# Altium schematic sheet 07_DDR_32.SchDoc(07_DDR_32)
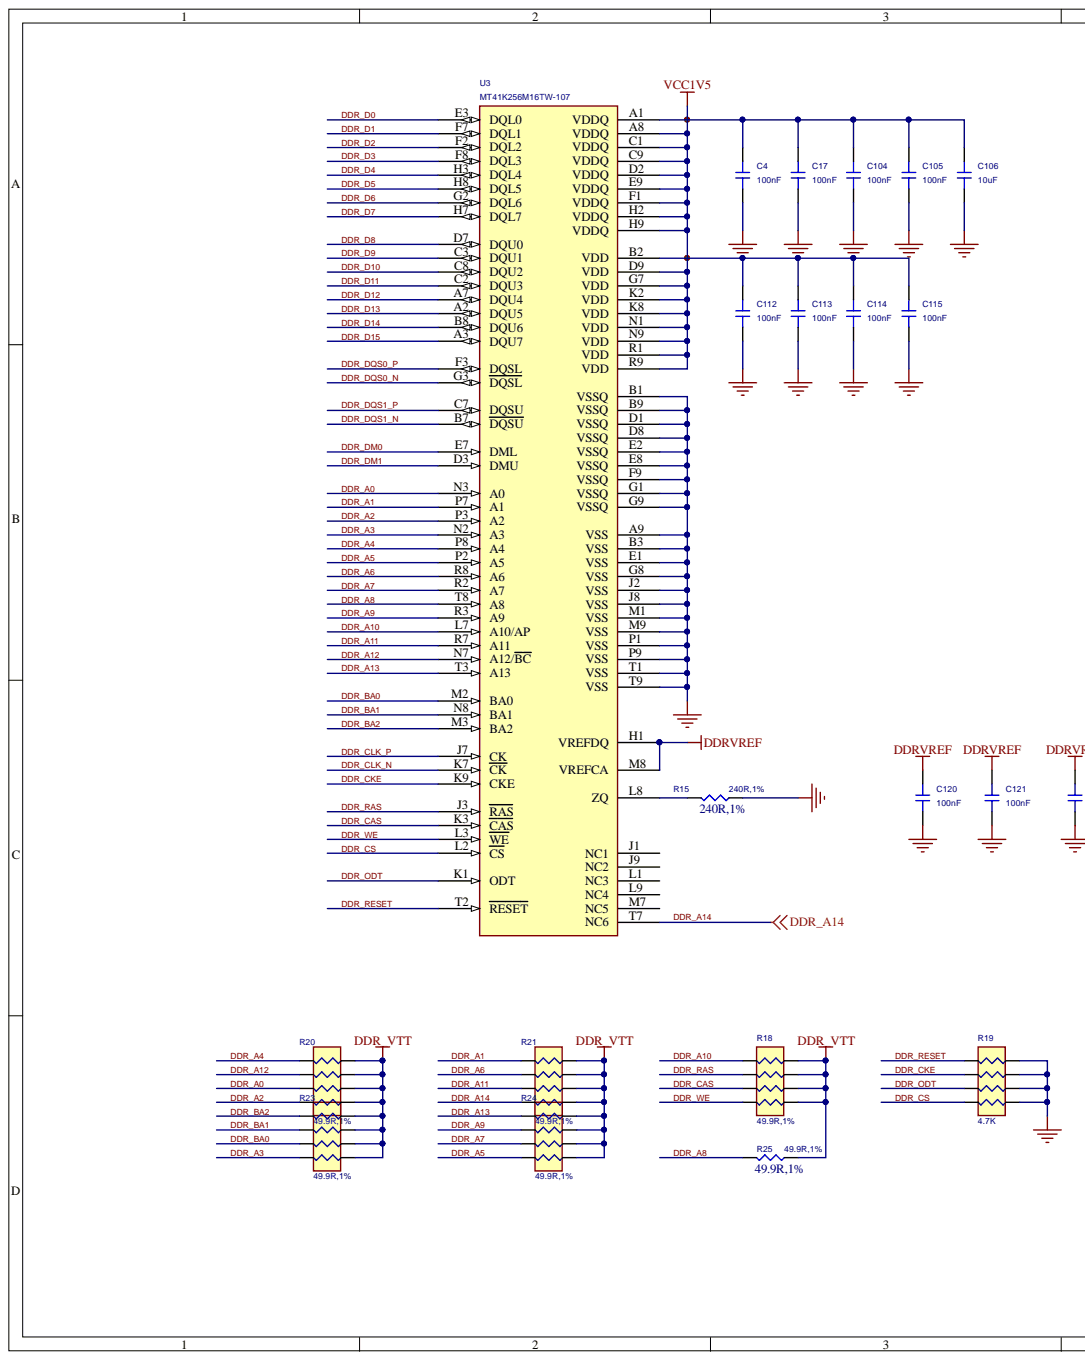
[diagram p0: 07_DDR_32.SchDoc, left]
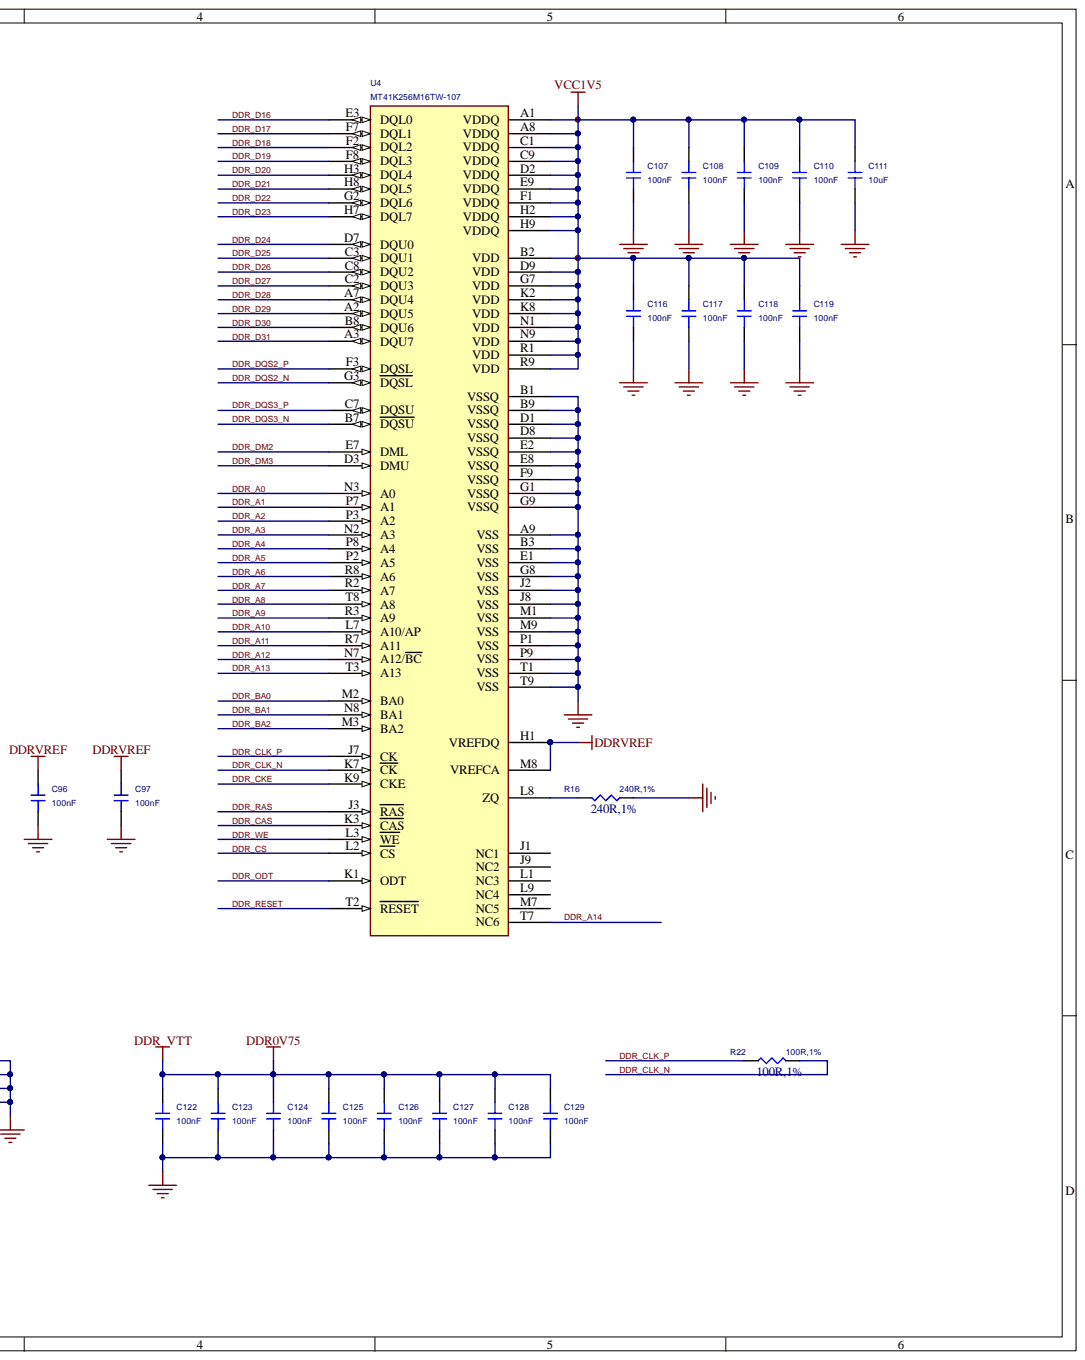
[diagram p1: 07_DDR_32.SchDoc, right]

## Parts
C4  100nF  [C0402]
C17  100nF  [C0402]
C96  100nF  [C0402]
C97  100nF  [C0402]
C104  100nF  [C0402]
C105  100nF  [C0402]
C106  10uF  [C0402]
C107  100nF  [C0402]
C108  100nF  [C0402]
C109  100nF  [C0402]
C110  100nF  [C0402]
C111  10uF  [C0402]
C112  100nF  [C0402]
C113  100nF  [C0402]
C114  100nF  [C0402]
C115  100nF  [C0402]
C116  100nF  [C0402]
C117  100nF  [C0402]
C118  100nF  [C0402]
C119  100nF  [C0402]
C120  100nF  [C0402]
C121  100nF  [C0402]
C122  100nF  [C0402]
C123  100nF  [C0402]
C124  100nF  [C0402]
C125  100nF  [C0402]
C126  100nF  [C0402]
C127  100nF  [C0402]
C128  100nF  [C0402]
C129  100nF  [C0402]
R15  240R,1%  [R0402]
R16  240R,1%  [R0402]
R18  49.9R,1%  [RA4_0402]
R19  4.7K  [RA4_0402]
R20  49.9R,1%  [RA4_0402]
R21  49.9R,1%  [RA4_0402]
R22  100R,1%  [R0402]
R23  49.9R,1%  [RA4_0402]
R24  49.9R,1%  [RA4_0402]
R25  49.9R,1%  [RES0402]
U3  MT41K256M16TW-107  [BGA96_80P7_5X13_3X1]
U4  MT41K256M16TW-107  [BGA96_80P7_5X13_3X1]

## Nets
DDR0V75: C122.2 C123.2 C124.2 C125.2 C126.2 C127.2 C128.2 C129.2 R18.5 R18.6 R18.7 R18.8 R20.5 R20.6 R20.7 R20.8 R21.5 R21.6 R21.7 R21.8 R23.5 R23.6 R23.7 R23.8 R24.5 R24.6 R24.7 R24.8 R25.2
DDRVREF: C96.2 C97.2 C120.2 C121.2 U3.H1(VREFDQ) U3.M8(VREFCA) U4.H1(VREFDQ) U4.M8(VREFCA)
GND: C4.1 C17.1 C96.1 C97.1 C104.1 C105.1 C106.1 C107.1 C108.1 C109.1 C110.1 C111.1 C112.1 C113.1 C114.1 C115.1 C116.1 C117.1 C118.1 C119.1 C120.1 C121.1 C122.1 C123.1 C124.1 C125.1 C126.1 C127.1 C128.1 C129.1 R15.2 R16.2 R19.5 R19.6 R19.7 R19.8 U3.A9(VSS) U3.B1(VSSQ) U3.B3(VSS) U3.B9(VSSQ) U3.D1(VSSQ) U3.D8(VSSQ) U3.E1(VSS) U3.E2(VSSQ) U3.E8(VSSQ) U3.F9(VSSQ) U3.G1(VSSQ) U3.G8(VSS) U3.G9(VSSQ) U3.J2(VSS) U3.J8(VSS) U3.M1(VSS) U3.M9(VSS) U3.P1(VSS) U3.P9(VSS) U3.T1(VSS) U3.T9(VSS) U4.A9(VSS) U4.B1(VSSQ) U4.B3(VSS) U4.B9(VSSQ) U4.D1(VSSQ) U4.D8(VSSQ) U4.E1(VSS) U4.E2(VSSQ) U4.E8(VSSQ) U4.F9(VSSQ) U4.G1(VSSQ) U4.G8(VSS) U4.G9(VSSQ) U4.J2(VSS) U4.J8(VSS) U4.M1(VSS) U4.M9(VSS) U4.P1(VSS) U4.P9(VSS) U4.T1(VSS) U4.T9(VSS)
VCC1V5: C4.2 C17.2 C104.2 C105.2 C106.2 C107.2 C108.2 C109.2 C110.2 C111.2 C112.2 C113.2 C114.2 C115.2 C116.2 C117.2 C118.2 C119.2 U3.A1(VDDQ) U3.A8(VDDQ) U3.B2(VDD) U3.C1(VDDQ) U3.C9(VDDQ) U3.D2(VDDQ) U3.D9(VDD) U3.E9(VDDQ) U3.F1(VDDQ) U3.G7(VDD) U3.H2(VDDQ) U3.H9(VDDQ) U3.K2(VDD) U3.K8(VDD) U3.N1(VDD) U3.N9(VDD) U3.R1(VDD) U3.R9(VDD) U4.A1(VDDQ) U4.A8(VDDQ) U4.B2(VDD) U4.C1(VDDQ) U4.C9(VDDQ) U4.D2(VDDQ) U4.D9(VDD) U4.E9(VDDQ) U4.F1(VDDQ) U4.G7(VDD) U4.H2(VDDQ) U4.H9(VDDQ) U4.K2(VDD) U4.K8(VDD) U4.N1(VDD) U4.N9(VDD) U4.R1(VDD) U4.R9(VDD)
DDR_A0: R20.3 U3.N3(A0) U4.N3(A0)
DDR_A1: R21.1 U3.P7(A1) U4.P7(A1)
DDR_A2: R20.4 U3.P3(A2) U4.P3(A2)
DDR_A3: R23.4 U3.N2(A3) U4.N2(A3)
DDR_A4: R20.1 U3.P8(A4) U4.P8(A4)
DDR_A5: R24.4 U3.P2(A5) U4.P2(A5)
DDR_A6: R21.2 U3.R8(A6) U4.R8(A6)
DDR_A7: R24.3 U3.R2(A7) U4.R2(A7)
DDR_A8: R25.1 U3.T8(A8) U4.T8(A8)
DDR_A9: R24.2 U3.R3(A9) U4.R3(A9)
DDR_A10: R18.1 U3.L7(A10/AP) U4.L7(A10/AP)
DDR_A11: R21.3 U3.R7(A11) U4.R7(A11)
DDR_A12: R20.2 U3.N7(A12/BC) U4.N7(A12/BC)
DDR_A13: R24.1 U3.T3(A13) U4.T3(A13)
DDR_A14: R21.4 U3.T7(NC6) U4.T7(NC6)
DDR_BA0: R23.3 U3.M2(BA0) U4.M2(BA0)
DDR_BA1: R23.2 U3.N8(BA1) U4.N8(BA1)
DDR_BA2: R23.1 U3.M3(BA2) U4.M3(BA2)
DDR_CAS: R18.3 U3.K3(CAS) U4.K3(CAS)
DDR_CKE: R19.2 U3.K9(CKE) U4.K9(CKE)
DDR_CLK_N: R22.1 U3.K7(CK) U4.K7(CK)
DDR_CLK_P: R22.2 U3.J7(CK) U4.J7(CK)
DDR_CS: R19.4 U3.L2(CS) U4.L2(CS)
DDR_D0: U3.E3(DQL0)
DDR_D1: U3.F7(DQL1)
DDR_D2: U3.F2(DQL2)
DDR_D3: U3.F8(DQL3)
DDR_D4: U3.H3(DQL4)
DDR_D5: U3.H8(DQL5)
DDR_D6: U3.G2(DQL6)
DDR_D7: U3.H7(DQL7)
DDR_D8: U3.D7(DQU0)
DDR_D9: U3.C3(DQU1)
DDR_D10: U3.C8(DQU2)
DDR_D11: U3.C2(DQU3)
DDR_D12: U3.A7(DQU4)
DDR_D13: U3.A2(DQU5)
DDR_D14: U3.B8(DQU6)
DDR_D15: U3.A3(DQU7)
DDR_D16: U4.E3(DQL0)
DDR_D17: U4.F7(DQL1)
DDR_D18: U4.F2(DQL2)
DDR_D19: U4.F8(DQL3)
DDR_D20: U4.H3(DQL4)
DDR_D21: U4.H8(DQL5)
DDR_D22: U4.G2(DQL6)
DDR_D23: U4.H7(DQL7)
DDR_D24: U4.D7(DQU0)
DDR_D25: U4.C3(DQU1)
DDR_D26: U4.C8(DQU2)
DDR_D27: U4.C2(DQU3)
DDR_D28: U4.A7(DQU4)
DDR_D29: U4.A2(DQU5)
DDR_D30: U4.B8(DQU6)
DDR_D31: U4.A3(DQU7)
DDR_DM0: U3.E7(DML)
DDR_DM1: U3.D3(DMU)
DDR_DM2: U4.E7(DML)
DDR_DM3: U4.D3(DMU)
DDR_DQS0_N: U3.G3(DQSL)
DDR_DQS0_P: U3.F3(DQSL)
DDR_DQS1_N: U3.B7(DQSU)
DDR_DQS1_P: U3.C7(DQSU)
DDR_DQS2_N: U4.G3(DQSL)
DDR_DQS2_P: U4.F3(DQSL)
DDR_DQS3_N: U4.B7(DQSU)
DDR_DQS3_P: U4.C7(DQSU)
DDR_ODT: R19.3 U3.K1(ODT) U4.K1(ODT)
DDR_RAS: R18.2 U3.J3(RAS) U4.J3(RAS)
DDR_RESET: R19.1 U3.T2(RESET) U4.T2(RESET)
DDR_WE: R18.4 U3.L3(WE) U4.L3(WE)
NetR15_1: R15.1 U3.L8(ZQ)
NetR16_1: R16.1 U4.L8(ZQ)

Not connected: U3 J1(NC1) J9(NC2) L1(NC3) L9(NC4) M7(NC5); U4 J1(NC1) J9(NC2) L1(NC3) L9(NC4) M7(NC5)

## Library data of the parts
C4 = CAP1_Dup1: CURRENT: CIMAX; DIST: FLAT; KNEE: CBMAX; MAX_TEMP: CTMAX; PSpiceTemplate: C^@REFDES %1 %2 ?TOLERANCE|C^@REFDES| @VALUE ?IC/IC=@IC/ TC=@TC1,@TC2 ?TOLERANCE|\n.model C^@REFDES CAP C=1 DEV=@TOLERANCE% TC1=@TC1 TC2=@TC2 VC1=@VC1 VC2=@VC2|; REUSE_ANNOTATE: TRUE; REUSE_ID: 13; SLOPE: CSMAX; TC1: 0; VOLTAGE: CMAX; Component Kind: Standard; Simulation: CAP1; TC2: 0; Value: 100nF; VC1: 0; VC2: 0
C17 = CAP1_Dup1: CURRENT: CIMAX; DIST: FLAT; KNEE: CBMAX; MAX_TEMP: CTMAX; PSpiceTemplate: C^@REFDES %1 %2 ?TOLERANCE|C^@REFDES| @VALUE ?IC/IC=@IC/ TC=@TC1,@TC2 ?TOLERANCE|\n.model C^@REFDES CAP C=1 DEV=@TOLERANCE% TC1=@TC1 TC2=@TC2 VC1=@VC1 VC2=@VC2|; REUSE_ANNOTATE: TRUE; REUSE_ID: 347; SLOPE: CSMAX; TC1: 0; VOLTAGE: CMAX; Component Kind: Standard; Simulation: CAP1; TC2: 0; Value: 100nF; VC1: 0; VC2: 0
C96 C97 C120 C121 = CAP1_Dup1: CURRENT: CIMAX; DIST: FLAT; KNEE: CBMAX; MAX_TEMP: CTMAX; PSpiceTemplate: C^@REFDES %1 %2 ?TOLERANCE|C^@REFDES| @VALUE ?IC/IC=@IC/ TC=@TC1,@TC2 ?TOLERANCE|\n.model C^@REFDES CAP C=1 DEV=@TOLERANCE% TC1=@TC1 TC2=@TC2 VC1=@VC1 VC2=@VC2|; REUSE_ANNOTATE: TRUE; REUSE_ID: 77; SLOPE: CSMAX; TC1: 0; VOLTAGE: CMAX; Component Kind: Standard; Simulation: CAP1; TC2: 0; Value: 100nF; VC1: 0; VC2: 0
C104 = CAP1_Dup1: CURRENT: CIMAX; DIST: FLAT; KNEE: CBMAX; MAX_TEMP: CTMAX; PSpiceTemplate: C^@REFDES %1 %2 ?TOLERANCE|C^@REFDES| @VALUE ?IC/IC=@IC/ TC=@TC1,@TC2 ?TOLERANCE|\n.model C^@REFDES CAP C=1 DEV=@TOLERANCE% TC1=@TC1 TC2=@TC2 VC1=@VC1 VC2=@VC2|; REUSE_ANNOTATE: TRUE; REUSE_ID: 349; SLOPE: CSMAX; TC1: 0; VOLTAGE: CMAX; Component Kind: Standard; Simulation: CAP1; TC2: 0; Value: 100nF; VC1: 0; VC2: 0
C105 = CAP1_Dup1: CURRENT: CIMAX; DIST: FLAT; KNEE: CBMAX; MAX_TEMP: CTMAX; PSpiceTemplate: C^@REFDES %1 %2 ?TOLERANCE|C^@REFDES| @VALUE ?IC/IC=@IC/ TC=@TC1,@TC2 ?TOLERANCE|\n.model C^@REFDES CAP C=1 DEV=@TOLERANCE% TC1=@TC1 TC2=@TC2 VC1=@VC1 VC2=@VC2|; REUSE_ANNOTATE: TRUE; REUSE_ID: 11; SLOPE: CSMAX; TC1: 0; VOLTAGE: CMAX; Component Kind: Standard; Simulation: CAP1; TC2: 0; Value: 100nF; VC1: 0; VC2: 0
C106 = CAP1_Dup1: CURRENT: CIMAX; DIST: FLAT; KNEE: CBMAX; MAX_TEMP: CTMAX; PSpiceTemplate: C^@REFDES %1 %2 ?TOLERANCE|C^@REFDES| @VALUE ?IC/IC=@IC/ TC=@TC1,@TC2 ?TOLERANCE|\n.model C^@REFDES CAP C=1 DEV=@TOLERANCE% TC1=@TC1 TC2=@TC2 VC1=@VC1 VC2=@VC2|; REUSE_ANNOTATE: TRUE; REUSE_ID: 348; SLOPE: CSMAX; TC1: 0; VOLTAGE: CMAX; Component Kind: Standard; Simulation: CAP1; TC2: 0; Value: 10uF; VC1: 0; VC2: 0
C107 = CAP1_Dup1: CURRENT: CIMAX; DIST: FLAT; KNEE: CBMAX; MAX_TEMP: CTMAX; PSpiceTemplate: C^@REFDES %1 %2 ?TOLERANCE|C^@REFDES| @VALUE ?IC/IC=@IC/ TC=@TC1,@TC2 ?TOLERANCE|\n.model C^@REFDES CAP C=1 DEV=@TOLERANCE% TC1=@TC1 TC2=@TC2 VC1=@VC1 VC2=@VC2|; REUSE_ANNOTATE: TRUE; REUSE_ID: 353; SLOPE: CSMAX; TC1: 0; VOLTAGE: CMAX; Component Kind: Standard; Simulation: CAP1; TC2: 0; Value: 100nF; VC1: 0; VC2: 0
C108 = CAP1_Dup1: CURRENT: CIMAX; DIST: FLAT; KNEE: CBMAX; MAX_TEMP: CTMAX; PSpiceTemplate: C^@REFDES %1 %2 ?TOLERANCE|C^@REFDES| @VALUE ?IC/IC=@IC/ TC=@TC1,@TC2 ?TOLERANCE|\n.model C^@REFDES CAP C=1 DEV=@TOLERANCE% TC1=@TC1 TC2=@TC2 VC1=@VC1 VC2=@VC2|; REUSE_ANNOTATE: TRUE; REUSE_ID: 9; SLOPE: CSMAX; TC1: 0; VOLTAGE: CMAX; Component Kind: Standard; Simulation: CAP1; TC2: 0; Value: 100nF; VC1: 0; VC2: 0
C109 = CAP1_Dup1: CURRENT: CIMAX; DIST: FLAT; KNEE: CBMAX; MAX_TEMP: CTMAX; PSpiceTemplate: C^@REFDES %1 %2 ?TOLERANCE|C^@REFDES| @VALUE ?IC/IC=@IC/ TC=@TC1,@TC2 ?TOLERANCE|\n.model C^@REFDES CAP C=1 DEV=@TOLERANCE% TC1=@TC1 TC2=@TC2 VC1=@VC1 VC2=@VC2|; REUSE_ANNOTATE: TRUE; REUSE_ID: 8; SLOPE: CSMAX; TC1: 0; VOLTAGE: CMAX; Component Kind: Standard; Simulation: CAP1; TC2: 0; Value: 100nF; VC1: 0; VC2: 0
C110 = CAP1_Dup1: CURRENT: CIMAX; DIST: FLAT; KNEE: CBMAX; MAX_TEMP: CTMAX; PSpiceTemplate: C^@REFDES %1 %2 ?TOLERANCE|C^@REFDES| @VALUE ?IC/IC=@IC/ TC=@TC1,@TC2 ?TOLERANCE|\n.model C^@REFDES CAP C=1 DEV=@TOLERANCE% TC1=@TC1 TC2=@TC2 VC1=@VC1 VC2=@VC2|; REUSE_ANNOTATE: TRUE; REUSE_ID: 346; SLOPE: CSMAX; TC1: 0; VOLTAGE: CMAX; Component Kind: Standard; Simulation: CAP1; TC2: 0; Value: 100nF; VC1: 0; VC2: 0
C111 = CAP1_Dup1: CURRENT: CIMAX; DIST: FLAT; KNEE: CBMAX; MAX_TEMP: CTMAX; PSpiceTemplate: C^@REFDES %1 %2 ?TOLERANCE|C^@REFDES| @VALUE ?IC/IC=@IC/ TC=@TC1,@TC2 ?TOLERANCE|\n.model C^@REFDES CAP C=1 DEV=@TOLERANCE% TC1=@TC1 TC2=@TC2 VC1=@VC1 VC2=@VC2|; REUSE_ANNOTATE: TRUE; REUSE_ID: 352; SLOPE: CSMAX; TC1: 0; VOLTAGE: CMAX; Component Kind: Standard; Simulation: CAP1; TC2: 0; Value: 10uF; VC1: 0; VC2: 0
C112 = CAP1_Dup1: CURRENT: CIMAX; DIST: FLAT; KNEE: CBMAX; MAX_TEMP: CTMAX; PSpiceTemplate: C^@REFDES %1 %2 ?TOLERANCE|C^@REFDES| @VALUE ?IC/IC=@IC/ TC=@TC1,@TC2 ?TOLERANCE|\n.model C^@REFDES CAP C=1 DEV=@TOLERANCE% TC1=@TC1 TC2=@TC2 VC1=@VC1 VC2=@VC2|; REUSE_ANNOTATE: TRUE; REUSE_ID: 2; SLOPE: CSMAX; TC1: 0; VOLTAGE: CMAX; Component Kind: Standard; Simulation: CAP1; TC2: 0; Value: 100nF; VC1: 0; VC2: 0
C113 = CAP1_Dup1: CURRENT: CIMAX; DIST: FLAT; KNEE: CBMAX; MAX_TEMP: CTMAX; PSpiceTemplate: C^@REFDES %1 %2 ?TOLERANCE|C^@REFDES| @VALUE ?IC/IC=@IC/ TC=@TC1,@TC2 ?TOLERANCE|\n.model C^@REFDES CAP C=1 DEV=@TOLERANCE% TC1=@TC1 TC2=@TC2 VC1=@VC1 VC2=@VC2|; REUSE_ANNOTATE: TRUE; REUSE_ID: 14; SLOPE: CSMAX; TC1: 0; VOLTAGE: CMAX; Component Kind: Standard; Simulation: CAP1; TC2: 0; Value: 100nF; VC1: 0; VC2: 0
C114 = CAP1_Dup1: CURRENT: CIMAX; DIST: FLAT; KNEE: CBMAX; MAX_TEMP: CTMAX; PSpiceTemplate: C^@REFDES %1 %2 ?TOLERANCE|C^@REFDES| @VALUE ?IC/IC=@IC/ TC=@TC1,@TC2 ?TOLERANCE|\n.model C^@REFDES CAP C=1 DEV=@TOLERANCE% TC1=@TC1 TC2=@TC2 VC1=@VC1 VC2=@VC2|; REUSE_ANNOTATE: TRUE; REUSE_ID: 4; SLOPE: CSMAX; TC1: 0; VOLTAGE: CMAX; Component Kind: Standard; Simulation: CAP1; TC2: 0; Value: 100nF; VC1: 0; VC2: 0
C115 = CAP1_Dup1: CURRENT: CIMAX; DIST: FLAT; KNEE: CBMAX; MAX_TEMP: CTMAX; PSpiceTemplate: C^@REFDES %1 %2 ?TOLERANCE|C^@REFDES| @VALUE ?IC/IC=@IC/ TC=@TC1,@TC2 ?TOLERANCE|\n.model C^@REFDES CAP C=1 DEV=@TOLERANCE% TC1=@TC1 TC2=@TC2 VC1=@VC1 VC2=@VC2|; REUSE_ANNOTATE: TRUE; REUSE_ID: 10; SLOPE: CSMAX; TC1: 0; VOLTAGE: CMAX; Component Kind: Standard; Simulation: CAP1; TC2: 0; Value: 100nF; VC1: 0; VC2: 0
C116 = CAP1_Dup1: CURRENT: CIMAX; DIST: FLAT; KNEE: CBMAX; MAX_TEMP: CTMAX; PSpiceTemplate: C^@REFDES %1 %2 ?TOLERANCE|C^@REFDES| @VALUE ?IC/IC=@IC/ TC=@TC1,@TC2 ?TOLERANCE|\n.model C^@REFDES CAP C=1 DEV=@TOLERANCE% TC1=@TC1 TC2=@TC2 VC1=@VC1 VC2=@VC2|; REUSE_ANNOTATE: TRUE; REUSE_ID: 350; SLOPE: CSMAX; TC1: 0; VOLTAGE: CMAX; Component Kind: Standard; Simulation: CAP1; TC2: 0; Value: 100nF; VC1: 0; VC2: 0
C117 = CAP1_Dup1: CURRENT: CIMAX; DIST: FLAT; KNEE: CBMAX; MAX_TEMP: CTMAX; PSpiceTemplate: C^@REFDES %1 %2 ?TOLERANCE|C^@REFDES| @VALUE ?IC/IC=@IC/ TC=@TC1,@TC2 ?TOLERANCE|\n.model C^@REFDES CAP C=1 DEV=@TOLERANCE% TC1=@TC1 TC2=@TC2 VC1=@VC1 VC2=@VC2|; REUSE_ANNOTATE: TRUE; REUSE_ID: 15; SLOPE: CSMAX; TC1: 0; VOLTAGE: CMAX; Component Kind: Standard; Simulation: CAP1; TC2: 0; Value: 100nF; VC1: 0; VC2: 0
C118 = CAP1_Dup1: CURRENT: CIMAX; DIST: FLAT; KNEE: CBMAX; MAX_TEMP: CTMAX; PSpiceTemplate: C^@REFDES %1 %2 ?TOLERANCE|C^@REFDES| @VALUE ?IC/IC=@IC/ TC=@TC1,@TC2 ?TOLERANCE|\n.model C^@REFDES CAP C=1 DEV=@TOLERANCE% TC1=@TC1 TC2=@TC2 VC1=@VC1 VC2=@VC2|; REUSE_ANNOTATE: TRUE; REUSE_ID: 3; SLOPE: CSMAX; TC1: 0; VOLTAGE: CMAX; Component Kind: Standard; Simulation: CAP1; TC2: 0; Value: 100nF; VC1: 0; VC2: 0
C119 = CAP1_Dup1: CURRENT: CIMAX; DIST: FLAT; KNEE: CBMAX; MAX_TEMP: CTMAX; PSpiceTemplate: C^@REFDES %1 %2 ?TOLERANCE|C^@REFDES| @VALUE ?IC/IC=@IC/ TC=@TC1,@TC2 ?TOLERANCE|\n.model C^@REFDES CAP C=1 DEV=@TOLERANCE% TC1=@TC1 TC2=@TC2 VC1=@VC1 VC2=@VC2|; REUSE_ANNOTATE: TRUE; REUSE_ID: 345; SLOPE: CSMAX; TC1: 0; VOLTAGE: CMAX; Component Kind: Standard; Simulation: CAP1; TC2: 0; Value: 100nF; VC1: 0; VC2: 0
C122 = CAP1_Dup1: CURRENT: CIMAX; DIST: FLAT; KNEE: CBMAX; MAX_TEMP: CTMAX; PSpiceTemplate: C^@REFDES %1 %2 ?TOLERANCE|C^@REFDES| @VALUE ?IC/IC=@IC/ TC=@TC1,@TC2 ?TOLERANCE|\n.model C^@REFDES CAP C=1 DEV=@TOLERANCE% TC1=@TC1 TC2=@TC2 VC1=@VC1 VC2=@VC2|; REUSE_ANNOTATE: TRUE; REUSE_ID: 162; SLOPE: CSMAX; TC1: 0; VOLTAGE: CMAX; Component Kind: Standard; Simulation: CAP1; TC2: 0; Value: 100nF; VC1: 0; VC2: 0
C123 = CAP1_Dup1: CURRENT: CIMAX; DIST: FLAT; KNEE: CBMAX; MAX_TEMP: CTMAX; PSpiceTemplate: C^@REFDES %1 %2 ?TOLERANCE|C^@REFDES| @VALUE ?IC/IC=@IC/ TC=@TC1,@TC2 ?TOLERANCE|\n.model C^@REFDES CAP C=1 DEV=@TOLERANCE% TC1=@TC1 TC2=@TC2 VC1=@VC1 VC2=@VC2|; REUSE_ANNOTATE: TRUE; REUSE_ID: 165; SLOPE: CSMAX; TC1: 0; VOLTAGE: CMAX; Component Kind: Standard; Simulation: CAP1; TC2: 0; Value: 100nF; VC1: 0; VC2: 0
C124 = CAP1_Dup1: CURRENT: CIMAX; DIST: FLAT; KNEE: CBMAX; MAX_TEMP: CTMAX; PSpiceTemplate: C^@REFDES %1 %2 ?TOLERANCE|C^@REFDES| @VALUE ?IC/IC=@IC/ TC=@TC1,@TC2 ?TOLERANCE|\n.model C^@REFDES CAP C=1 DEV=@TOLERANCE% TC1=@TC1 TC2=@TC2 VC1=@VC1 VC2=@VC2|; REUSE_ANNOTATE: TRUE; REUSE_ID: 163; SLOPE: CSMAX; TC1: 0; VOLTAGE: CMAX; Component Kind: Standard; Simulation: CAP1; TC2: 0; Value: 100nF; VC1: 0; VC2: 0
C125 = CAP1_Dup1: CURRENT: CIMAX; DIST: FLAT; KNEE: CBMAX; MAX_TEMP: CTMAX; PSpiceTemplate: C^@REFDES %1 %2 ?TOLERANCE|C^@REFDES| @VALUE ?IC/IC=@IC/ TC=@TC1,@TC2 ?TOLERANCE|\n.model C^@REFDES CAP C=1 DEV=@TOLERANCE% TC1=@TC1 TC2=@TC2 VC1=@VC1 VC2=@VC2|; REUSE_ANNOTATE: TRUE; REUSE_ID: 164; SLOPE: CSMAX; TC1: 0; VOLTAGE: CMAX; Component Kind: Standard; Simulation: CAP1; TC2: 0; Value: 100nF; VC1: 0; VC2: 0
C126 = CAP1_Dup1: CURRENT: CIMAX; DIST: FLAT; KNEE: CBMAX; MAX_TEMP: CTMAX; PSpiceTemplate: C^@REFDES %1 %2 ?TOLERANCE|C^@REFDES| @VALUE ?IC/IC=@IC/ TC=@TC1,@TC2 ?TOLERANCE|\n.model C^@REFDES CAP C=1 DEV=@TOLERANCE% TC1=@TC1 TC2=@TC2 VC1=@VC1 VC2=@VC2|; REUSE_ANNOTATE: TRUE; REUSE_ID: 167; SLOPE: CSMAX; TC1: 0; VOLTAGE: CMAX; Component Kind: Standard; Simulation: CAP1; TC2: 0; Value: 100nF; VC1: 0; VC2: 0
C127 = CAP1_Dup1: CURRENT: CIMAX; DIST: FLAT; KNEE: CBMAX; MAX_TEMP: CTMAX; PSpiceTemplate: C^@REFDES %1 %2 ?TOLERANCE|C^@REFDES| @VALUE ?IC/IC=@IC/ TC=@TC1,@TC2 ?TOLERANCE|\n.model C^@REFDES CAP C=1 DEV=@TOLERANCE% TC1=@TC1 TC2=@TC2 VC1=@VC1 VC2=@VC2|; REUSE_ANNOTATE: TRUE; REUSE_ID: 170; SLOPE: CSMAX; TC1: 0; VOLTAGE: CMAX; Component Kind: Standard; Simulation: CAP1; TC2: 0; Value: 100nF; VC1: 0; VC2: 0
C128 = CAP1_Dup1: CURRENT: CIMAX; DIST: FLAT; KNEE: CBMAX; MAX_TEMP: CTMAX; PSpiceTemplate: C^@REFDES %1 %2 ?TOLERANCE|C^@REFDES| @VALUE ?IC/IC=@IC/ TC=@TC1,@TC2 ?TOLERANCE|\n.model C^@REFDES CAP C=1 DEV=@TOLERANCE% TC1=@TC1 TC2=@TC2 VC1=@VC1 VC2=@VC2|; REUSE_ANNOTATE: TRUE; REUSE_ID: 168; SLOPE: CSMAX; TC1: 0; VOLTAGE: CMAX; Component Kind: Standard; Simulation: CAP1; TC2: 0; Value: 100nF; VC1: 0; VC2: 0
C129 = CAP1_Dup1: CURRENT: CIMAX; DIST: FLAT; KNEE: CBMAX; MAX_TEMP: CTMAX; PSpiceTemplate: C^@REFDES %1 %2 ?TOLERANCE|C^@REFDES| @VALUE ?IC/IC=@IC/ TC=@TC1,@TC2 ?TOLERANCE|\n.model C^@REFDES CAP C=1 DEV=@TOLERANCE% TC1=@TC1 TC2=@TC2 VC1=@VC1 VC2=@VC2|; REUSE_ANNOTATE: TRUE; REUSE_ID: 166; SLOPE: CSMAX; TC1: 0; VOLTAGE: CMAX; Component Kind: Standard; Simulation: CAP1; TC2: 0; Value: 100nF; VC1: 0; VC2: 0
R15 = RES1: DIST: FLAT; MAX_TEMP: RTMAX; POWER: RMAX; PSpiceTemplate: R^@REFDES %1 %2 ?TOLERANCE|R^@REFDES| @VALUE TC=@TC1,@TC2 ?TOLERANCE|\n.model R^@REFDES RES R=1 DEV=@TOLERANCE% TC1=@TC1 TC2=@TC2|; REUSE_ANNOTATE: TRUE; REUSE_ID: 1; SLOPE: RSMAX; TC1: 0; VOLTAGE: RVMAX; Component Kind: Standard; Simulation: RES1; TC2: 0; Value: 240R,1%
R16 = RES1: DIST: FLAT; MAX_TEMP: RTMAX; POWER: RMAX; PSpiceTemplate: R^@REFDES %1 %2 ?TOLERANCE|R^@REFDES| @VALUE TC=@TC1,@TC2 ?TOLERANCE|\n.model R^@REFDES RES R=1 DEV=@TOLERANCE% TC1=@TC1 TC2=@TC2|; REUSE_ANNOTATE: TRUE; REUSE_ID: 351; SLOPE: RSMAX; TC1: 0; VOLTAGE: RVMAX; Component Kind: Standard; Simulation: RES1; TC2: 0; Value: 240R,1%
R18 R20 R21 R23 R24 = RA-4: REUSE_ANNOTATE: TRUE; REUSE_ID: 202; Component Kind: Standard
R19 = RA-4: REUSE_ANNOTATE: TRUE; REUSE_ID: 203; Component Kind: Standard
R22 = RES1: DIST: FLAT; MAX_TEMP: RTMAX; POWER: RMAX; PSpiceTemplate: R^@REFDES %1 %2 ?TOLERANCE|R^@REFDES| @VALUE TC=@TC1,@TC2 ?TOLERANCE|\n.model R^@REFDES RES R=1 DEV=@TOLERANCE% TC1=@TC1 TC2=@TC2|; REUSE_ANNOTATE: TRUE; REUSE_ID: 6; SLOPE: RSMAX; TC1: 0; VOLTAGE: RVMAX; Component Kind: Standard; Simulation: RES1; TC2: 0; Value: 100R,1%
R25 = RES1: DIST: FLAT; MAX_TEMP: RTMAX; POWER: RMAX; PSpiceTemplate: R^@REFDES %1 %2 ?TOLERANCE|R^@REFDES| @VALUE TC=@TC1,@TC2 ?TOLERANCE|\n.model R^@REFDES RES R=1 DEV=@TOLERANCE% TC1=@TC1 TC2=@TC2|; REUSE_ANNOTATE: TRUE; REUSE_ID: 204; SLOPE: RSMAX; TC1: 0; VOLTAGE: RVMAX; Component Kind: Standard; Simulation: RES1; TC2: 0; Value: 49.9R,1%
U3 = K4B2G1646C-HCH9: REUSE_ANNOTATE: TRUE; REUSE_ID: 354; Component Kind: Standard
U4 = K4B2G1646C-HCH9: REUSE_ANNOTATE: TRUE; REUSE_ID: 5; Component Kind: Standard
